# Altium schematic sheet CV2018-DIFF.SchDoc(CV2018-DIFF)
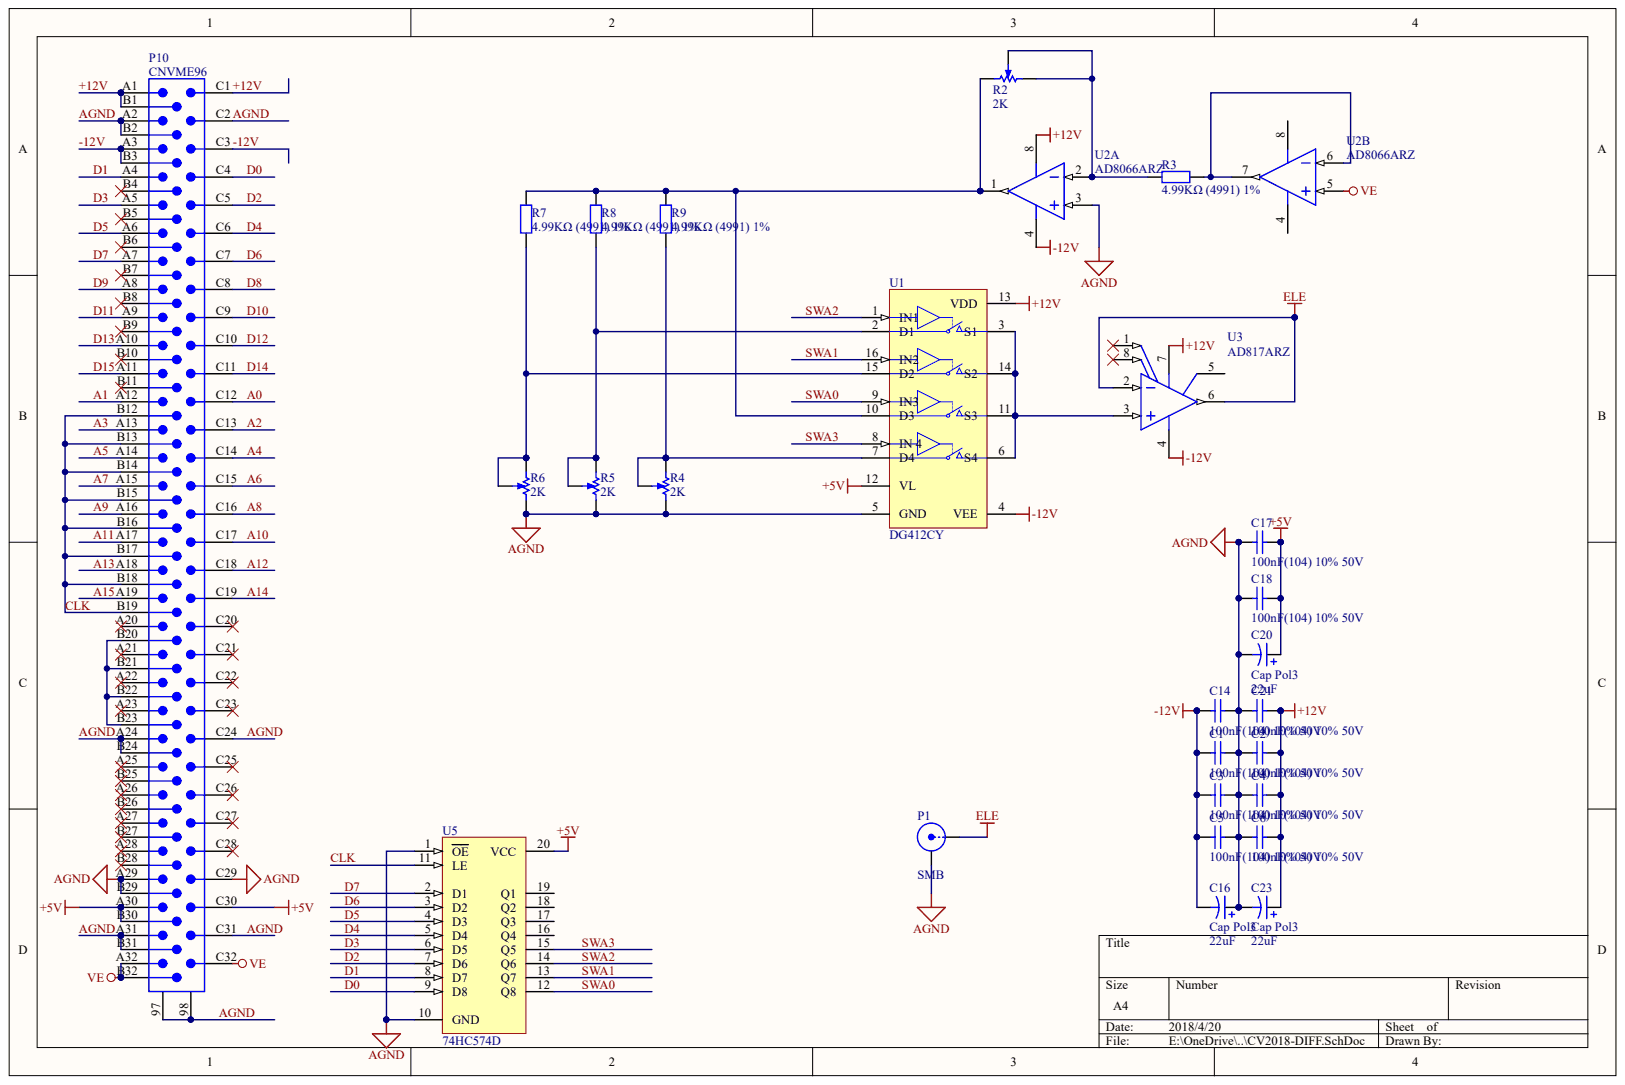
[diagram p0: CV2018-DIFF.SchDoc]

## Parts
C1  100nF(104) 10% 50V
C2  100nF(104) 10% 50V
C3  100nF(104) 10% 50V
C4  100nF(104) 10% 50V
C5  100nF(104) 10% 50V
C6  100nF(104) 10% 50V
C14  100nF(104) 10% 50V
C16  Cap Pol3  value 22uF
C17  100nF(104) 10% 50V
C18  100nF(104) 10% 50V
C20  Cap Pol3  value 22uF
C21  100nF(104) 10% 50V
C23  Cap Pol3  value 22uF
P1  SMB
P10  CNVME96
R2  2K
R3  4.99KΩ (4991) ±1%
R4  2K
R5  2K
R6  2K
R7  4.99KΩ (4991) ±1%
R8  4.99KΩ (4991) ±1%
R9  4.99KΩ (4991) ±1%
U1  DG412CY
U2  AD8066ARZ  (parts A,B)
U3  AD817ARZ
U5  74HC574D

## Nets
+5V: C17.2 C18.2 C20.1 P10.A30 P10.B30 P10.C30 U1.12(VL) U5.20(VCC)
ELE: P1.1 U3.2 U3.6
VE: P10.A32 P10.B32 P10.C32 U2.5
+12V: C2.2 C4.2 C6.2 C21.2 C23.1 P10.A1 P10.B1 P10.C1 U1.13(VDD) U2.8 U3.7
-12V: C1.1 C3.1 C5.1 C14.1 C16.2 P10.A3 P10.B3 P10.C3 U1.4(VEE) U2.4 U3.4
A0: P10.C12
A1: P10.A12
A2: P10.C13
A3: P10.A13
A4: P10.C14
A5: P10.A14
A6: P10.C15
A7: P10.A15
A8: P10.C16
A9: P10.A16
A10: P10.C17
A11: P10.A17
A12: P10.C18
A13: P10.A18
A14: P10.C19
A15: P10.A19
AGND: C1.2 C2.1 C3.2 C4.1 C5.2 C6.1 C14.2 C16.1 C17.1 C18.1 C20.2 C21.1 C23.2 P1.2 P10.97 P10.98 P10.A2 P10.A24 P10.A29 P10.A31 P10.B2 P10.B24 P10.B29 P10.B31 P10.C2 P10.C24 P10.C29 P10.C31 R4.CW R5.CW R6.CW U1.5(GND) U2.3 U5.1(OE) U5.10(GND)
CLK: P10.B12 P10.B13 P10.B14 P10.B15 P10.B16 P10.B17 P10.B18 P10.B19 U5.11(LE)
D0: P10.C4 U5.9(D8)
D1: P10.A4 U5.8(D7)
D2: P10.C5 U5.7(D6)
D3: P10.A5 U5.6(D5)
D4: P10.C6 U5.5(D4)
D5: P10.A6 U5.4(D3)
D6: P10.C7 U5.3(D2)
D7: P10.A7 U5.2(D1)
D8: P10.C8
D9: P10.A8
D10: P10.C9
D11: P10.A9
D12: P10.C10
D13: P10.A10
D14: P10.C11
D15: P10.A11
SWA0: U1.9(IN3) U5.12(Q8)
SWA1: U1.16(IN2) U5.13(Q7)
SWA2: U1.1(IN1) U5.14(Q6)
SWA3: U1.8(IN_4) U5.15(Q5)
NetP10_B20: P10.B20 P10.B21 P10.B22 P10.B23
NetR2_CCW: R2.CCW R2.W R3.1 U2.2
NetR2_CW: R2.CW R7.2 R8.2 R9.2 U1.10(D3) U2.1
NetR3_2: R3.2 U2.6 U2.7
NetR4_CCW: R4.CCW R4.W R9.1 U1.7(D4)
NetR5_CCW: R5.CCW R5.W R8.1 U1.2(D1)
NetR6_CCW: R6.CCW R6.W R7.1 U1.15(D2)
NetU1_3: U1.3(S1) U1.6(S4) U1.11(S3) U1.14(S2) U3.3

Not connected: P10 A20 A21 A22 A23 A25 A26 A27 A28 B4 B5 B6 B7 B8 B9 B10 B11 B25 B26 B27 B28 C20 C21 C22 C23 C25 C26 C27 C28; U3 1 5 8; U5 16(Q4) 17(Q3) 18(Q2) 19(Q1)

## Library data of the parts
C20 = (no symbol name): Value: 22uF; Published: 8-Jun-2000; LatestRevisionDate: 29-May-2009; LatestRevisionNote: IPC-7351 Footprint Added.; PackageReference: C0805; PackageDescription: Chip Capacitor; Publisher: Altium Limited
P10 = (no symbol name): Text Field6: Alternate Layout Available; Text Field7: Published 14-Feb-2002
U2 = (no symbol name): Generic Part Number: AD8066; Manufacturer: Analog Devices; Amplifiers Per Package: 2; Input Bias Current: 2pA; Input Offset Voltage: 400uV; Iq/Amp: 7.4mA; Slew Rate: 180V/us; Small Signal Bandwidth: 145MHz; V Span Max: 24V; V Span Min: 5V; DatasheetVersion: Rev. J; PackageVersion: 060506-A; PackageReference: R-8; PackageDescription: 8-Pin Standard Small Outline Package, Body 3.9 x 4.9 mm, Pitch 1.27 mm; Supplier 1: Digi-Key; Supplier Part Number 1: AD8066ARZ-ND; Supplier 2: Farnell; Supplier Part Number 2: 8621535; Supplier 3: Newark; Supplier Part Number 3: 59K6322; Mounting Technology: Surface Mount; Code_JEDEC: MS-012-AA; PartNumber: AD8066ARZ; ComponentLink1Description: Manufacturer URL; ComponentLink1URL: http://www.analog.com/en/index.html; ComponentLink2Description: Datasheet; ComponentLink2URL: http://www.analog.com/static/imported-files/data_sheets/AD8065_8066.pdf; ComponentLink3Description: Package Specification; ComponentLink3URL: http://www.analog.com/static/imported-files/packages/PKG_PDF/SOIC_Narrow%20(R)/R_8.pdf; ComponentLink4Description: Reference Circuit; ComponentLink4URL: http://www.analog.com/en/all-operational-amplifiers-op-amps/operational-amplifiers-op-amps/ad8066/products/product.html#product-recommendations
U3 = (no symbol name): Generic Part Number: AD817; Manufacturer: Analog Devices; Amplifiers Per Package: 1; Input Bias Current: 3.3uA; Input Offset Voltage: 500uV; Iq/Amp: 7.5mA; Slew Rate: 350V/us; Small Signal Bandwidth: 50MHz; V Span Max: 36V; V Span Min: 5V; DatasheetVersion: Rev. B; PackageVersion: 060506-A; PackageReference: R-8; PackageDescription: 8-Pin Standard Small Outline Package, Body 3.9 x 4.9 mm, Pitch 1.27 mm; Supplier 1: Digi-Key; Supplier Part Number 1: AD817ARZ-ND; Supplier 2: Farnell; Supplier Part Number 2: 1660992; Supplier 3: Newark; Supplier Part Number 3: 88H0179; Mounting Technology: Surface Mount; Code_JEDEC: MS-012-AA; PartNumber: AD817ARZ; ComponentLink1Description: Manufacturer URL; ComponentLink1URL: http://www.analog.com/en/index.html; ComponentLink2Description: Datasheet; ComponentLink2URL: http://www.analog.com/static/imported-files/data_sheets/AD817.pdf; ComponentLink3Description: Package Specification; ComponentLink3URL: http://www.analog.com/static/imported-files/packages/PKG_PDF/SOIC_Narrow%20(R)/R_8.pdf
C1-C6 C14 C16-C18 C21 C23 P1 R2-R9 U1 U5 = (no symbol name)
  C1-C6 C14 C17 C18 C21: ComponentLink1Description: 供应商链接; ComponentLink1URL: http://www.szlcsc.com/product/details_50686.html; Package: 0805; Supplier: LC; SuppliersPartNumber: C49678
  C16 C23: Published: 8-Jun-2000; LatestRevisionDate: 29-May-2009; LatestRevisionNote: IPC-7351 Footprint Added.; PackageReference: C0805; PackageDescription: Chip Capacitor
  P1: LatestRevisionDate: 17-Jul-2002; LatestRevisionNote: Re-released for DXP Platform.
  R2 R4-R6: Published: 17-Dec-2003; DatasheetVersion: Oct-2003; PackageReference: POT4MM-2; PackageDescription: Square Trimming Potentiometer; ComponentLink1Description: Manufacturer Link; ComponentLink1URL: http://bourns.com/2/search.html
  R3 R7-R9: ComponentLink1Description: 供应商链接; ComponentLink1URL: http://www.szlcsc.com/product/details_18365.html; Package: 0805; Supplier: LC; SuppliersPartNumber: C17677
  U1: Published: 16-Jan-2001; LatestRevisionDate: 13-Apr-2006; LatestRevisionNote: IPC-7351 Footprint Added.; DatasheetVersion: Aug-1995; PackageReference: NSO16; PackageDescription: 16-Pin Small Outline Integrated Circuit 1.27 mm Pitch; Code_JEDEC: MS-012-AC; Code_IPC: SOIC127P600-16; ComponentLink1Description: Manufacturer Link; ComponentLink1URL: http://www.maxim-ic.com/; ComponentLink2Description: Datasheet; ComponentLink2URL: http://pdfserv.maxim-ic.com/en/ds/DG411-DG413.pdf
  U5: ComponentLink1Description: 供应商链接; ComponentLink1URL: http://www.szlcsc.com/product/details_6059.html; Package: SOIC-20_300mil; Supplier: LC; SuppliersPartNumber: C5608
  C16 C23 P1 R2 R4-R6 U1: Publisher: Altium Limited
